# Altium schematic sheet Peripherals.SchDoc
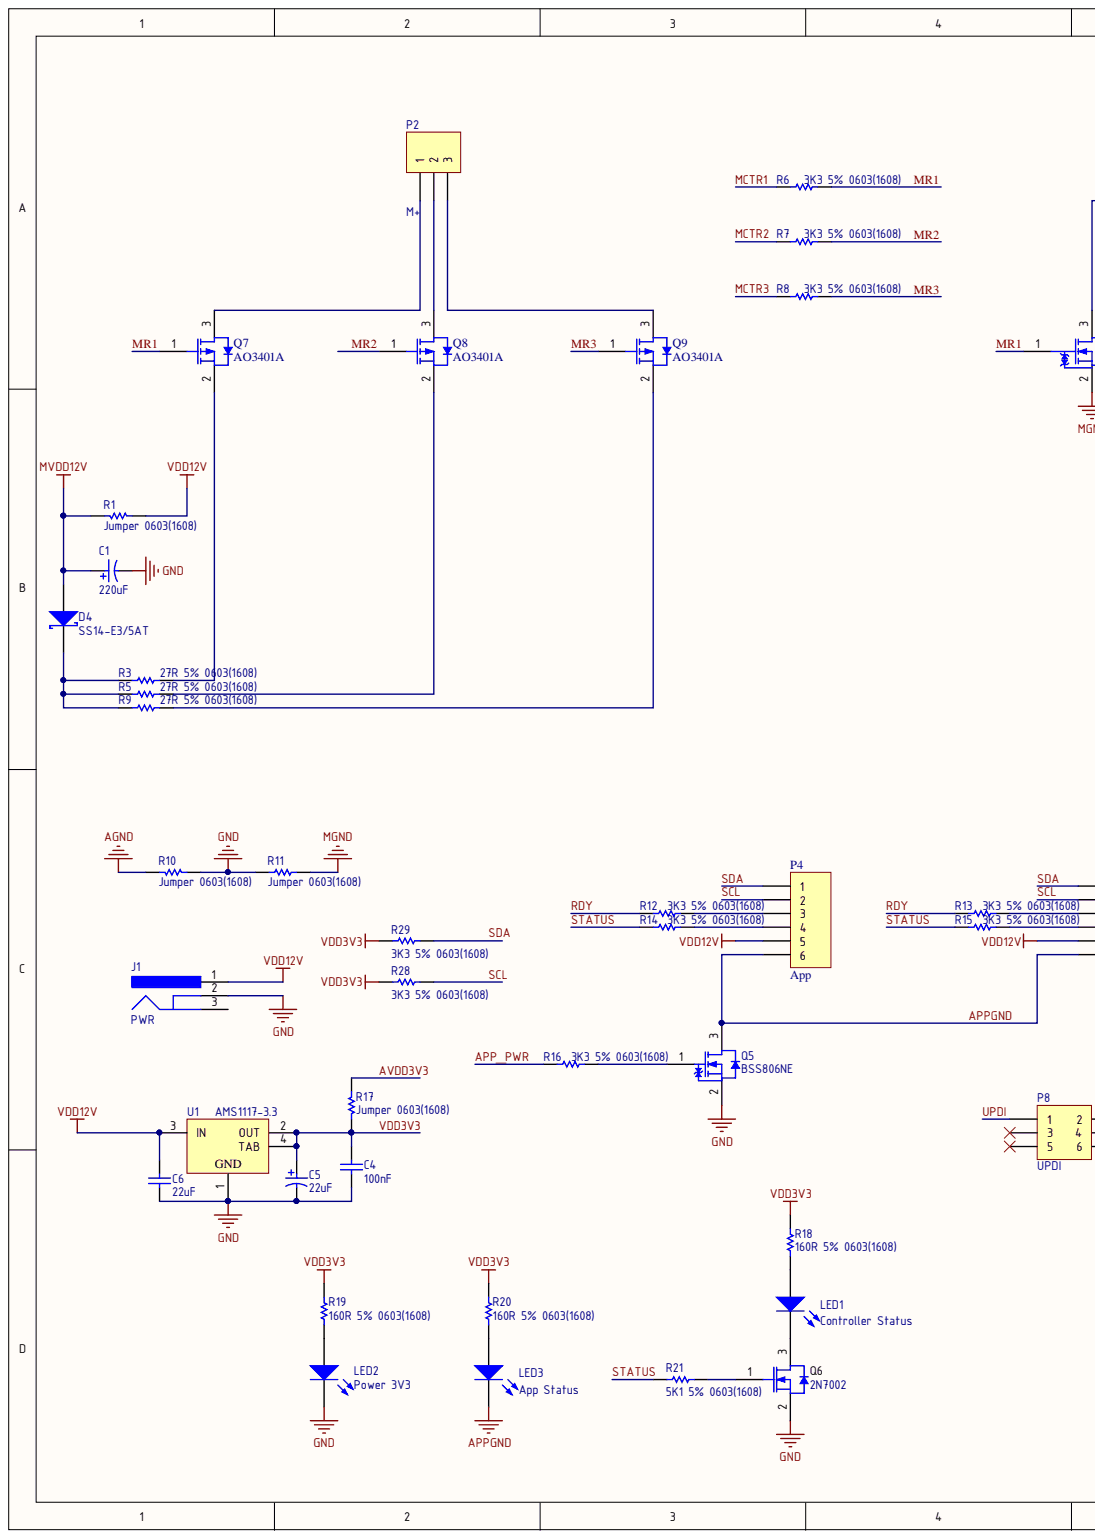
[diagram p0: Peripherals.SchDoc, left]
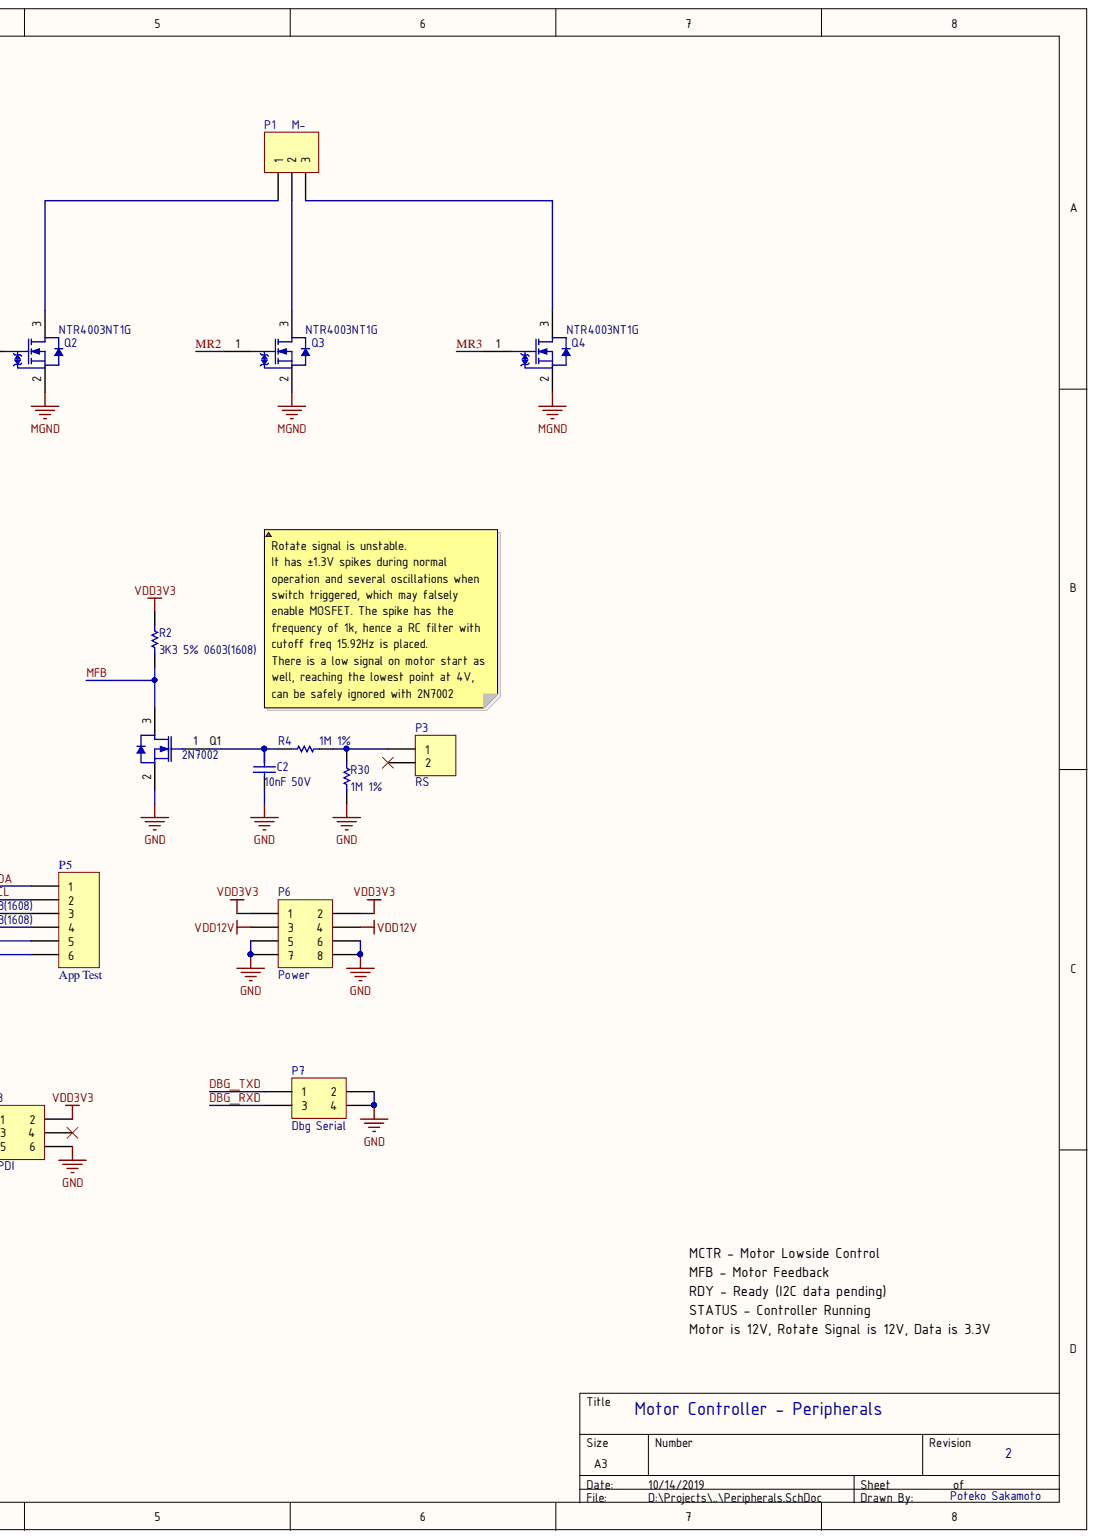
[diagram p1: Peripherals.SchDoc, right]

## Parts
C1  220uF  [PNSC-ZA-G_M]
C2  10nF 50V  value 10nF  [CAPC0603(1608)100_M]
C4  100nF  [CAPC0603(1608)100_M]
C5  22uF  [KEMT-A-3216-18-2_M]
C6  22uF  [CAPC0805(2012)145_M]
D4  SS14-E3/5AT  [VISH-DO-214AC-2_V]
J1  PWR  [KYCN-KLDX-0202-A_V]
LED1  Controller Status  [0805_A]
LED2  Power 3V3  [0805_A]
LED3  App Status  [0805_A]
P1  M-  [HDR1X3]
P2  M+  [HDR1X3]
P3  RS  [HDR1X2]
P4  App  [PH2.0-WS-6P]
P5  App Test  [HDR1X6]
P6  Power  [HDR2X4]
P7  Dbg Serial  [HDR2X2]
P8  UPDI  [HDR2X3]
Q1  2N7002  [INF-PG-SOT23_M]
Q2  NTR4003NT1G  [ONSC-SOT-23-3-318-08_V]
Q3  NTR4003NT1G  [ONSC-SOT-23-3-318-08_V]
Q4  NTR4003NT1G  [ONSC-SOT-23-3-318-08_V]
Q5  BSS806NE  [INF-PG-SOT23-3_N]
Q6  2N7002  [INF-PG-SOT23_M]
Q7  AO3401A  [AO-SOT-23-3L_V]
Q8  AO3401A  [AO-SOT-23-3L_V]
Q9  AO3401A  [AO-SOT-23-3L_V]
R1  Jumper 0603(1608)  [RESC0603(1608)_M]
R2  3K3 5% 0603(1608)  [RESC0603(1608)_M]
R3  27R 5% 0603(1608)  [RESC0603(1608)_M]
R4  1M 1%  [RESC0603(1608)_M]
R5  27R 5% 0603(1608)  [RESC0603(1608)_M]
R6  3K3 5% 0603(1608)  [RESC0603(1608)_M]
R7  3K3 5% 0603(1608)  [RESC0603(1608)_M]
R8  3K3 5% 0603(1608)  [RESC0603(1608)_M]
R9  27R 5% 0603(1608)  [RESC0603(1608)_M]
R10  Jumper 0603(1608)  [RESC0603(1608)_M]
R11  Jumper 0603(1608)  [RESC0603(1608)_M]
R12  3K3 5% 0603(1608)  [RESC0603(1608)_M]
R13  3K3 5% 0603(1608)  [RESC0603(1608)_M]
R14  3K3 5% 0603(1608)  [RESC0603(1608)_M]
R15  3K3 5% 0603(1608)  [RESC0603(1608)_M]
R16  3K3 5% 0603(1608)  [RESC0603(1608)_M]
R17  Jumper 0603(1608)  [RESC0603(1608)_M]
R18  160R 5% 0603(1608)  [RESC0603(1608)_M]
R19  160R 5% 0603(1608)  [RESC0603(1608)_M]
R20  160R 5% 0603(1608)  [RESC0603(1608)_M]
R21  5K1 5% 0603(1608)  [RESC0603(1608)_M]
R28  3K3 5% 0603(1608)  [RESC0603(1608)_M]
R29  3K3 5% 0603(1608)  [RESC0603(1608)_M]
R30  1M 1%  [RESC0603(1608)_M]
U1  AMS1117-3.3  [DCY0004A_M]

## Nets
AGND: R10.2
GND: C1.2 C2.1 C4.1 C5.2 C6.1 J1.2 LED2.1 P6.5 P6.6 P6.7 P6.8 P7.2 P7.4 P8.6 Q1.2 Q5.2 Q6.2 R10.1 R11.1 R30.1 U1.1
MGND: Q2.2 Q3.2 Q4.2 R11.2
MVDD12V: C1.1 D4.1 R1.2
VDD12V: C6.2 J1.1 P4.5 P5.5 P6.3 P6.4 R1.1 U1.3(IN)
APP_PWR: R16.2
APPGND: LED3.1 P4.6 P5.6 Q5.3
AVDD3V3: R17.1
DBG_RXD: P7.3
DBG_TXD: P7.1
MCTR1: R6.2
MCTR2: R7.2
MCTR3: R8.2
MFB: Q1.3 R2.2
MR1: Q2.1 Q7.1 R6.1
MR2: Q3.1 Q8.1 R7.1
MR3: Q4.1 Q9.1 R8.1
RDY: R12.2 R13.2
SCL: P4.2 P5.2 R28.2
SDA: P4.1 P5.1 R29.2
STATUS: R14.2 R15.2 R21.1
UPDI: P8.1
VDD3V3: C4.2 C5.1 P6.1 P6.2 P8.2 R2.1 R17.2 R18.2 R19.2 R20.2 R28.1 R29.1 U1.2(OUT) U1.4(TAB)
NetC2_2: C2.2 Q1.1 R4.1
NetD4_2: D4.2 R3.1 R5.1 R9.1
NetLED1_1: LED1.1 Q6.3
NetLED1_2: LED1.2 R18.1
NetLED2_2: LED2.2 R19.1
NetLED3_2: LED3.2 R20.1
NetP1_1: P1.1 Q2.3
NetP1_2: P1.2 Q3.3
NetP1_3: P1.3 Q4.3
NetP2_1: P2.1 Q7.3
NetP2_2: P2.2 Q8.3
NetP2_3: P2.3 Q9.3
NetP3_1: P3.1 R4.2 R30.2
NetP4_3: P4.3 R12.1
NetP4_4: P4.4 R14.1
NetP5_3: P5.3 R13.1
NetP5_4: P5.4 R15.1
NetQ5_1: Q5.1 R16.1
NetQ6_1: Q6.1 R21.2
NetQ7_2: Q7.2 R3.2
NetQ8_2: Q8.2 R5.2
NetQ9_2: Q9.2 R9.2

Not connected: J1 3; P3 2; P8 3 4 5

## Library data of the parts
C1 = CMP-2000-06221-1: Conductive Polymer Hybrid Aluminum Electrolytic Capacitor, 220 uF, +/- 20%, 50 V, -55 to 105 degC, 2-Pin SMD (G), RoHS, Tape and Reel; Brand: Panasonic; Capacitance: 100uF; Case Diameter: 10mm; Case Height: 10.5mm; Case Length: 10.2mm; ESR: 28mOhms; Load Life: 10000Hour; Ripple Current: 2A; Voltage Rating DC: 50V; Component Kind: Standard; Datasheet URL: https://industrial.panasonic.com/cdbs/www-data/pdf/RDD0000/DMD0000COL56.pdf; Datasheet Version: 06/2016; Factory Pack Quantity: 500; Height: 10.5mm; Library Name: Altium Content Vault; Manufacturer: Panasonic; Manufacturer URL: http://www.panasonic.com/; Maximum Operating Temperature: +105C; Minimum Operating Temperature: -55C; Mounting Technology: SurfaceMount; Package Description: 2-Pin Surface Mount Device, Body 10.3 x 10.3 mm; Package Reference: ZA-G; Packaging: Reel; Product: AluminumElectrolyticCapacitors; Product Category: AluminumOrganicPolymerCapacitors; RoHS: Details; Series: ZA; Termination Style: SMD/SMT; Tolerance: 20%
C2 = CMP-1035-02320-1: CAP 10nF 50V ±5% 0603 (1608 Metric) Thickness 1mm SMD; Case-EIA: 0603; Case-Metric: 1608; Tolerance: Â±5%; Component Kind: Standard; Library Name: Altium Content Vault; Max Thickness: 1 mm; Rated Voltage: 50 V; Technology: SMT
C4 = CMP-1035-02592-1: CAP 100nF 50V ±10% 0603 (1608 Metric) Thickness 1mm SMD; Case-EIA: 0603; Case-Metric: 1608; Tolerance: Â±10%; Component Kind: Standard; Library Name: Altium Content Vault; Max Thickness: 1 mm; Rated Voltage: 50 V; Technology: SMT; Value: 100nF
C5 = CMP-2000-05173-1: Capacitor, Tantalum, 22 uF, +/- 10%, 16 V, -55 to 125 degC, 2-Pin SMD (1206), RoHS, Tape and Reel; Manufacturer: Kemet; Capacitance: 10uF; Case Style: Molded; DCL: 1.6uA; DF: 8%; ESR: 7ohm; Insulation: NotRequired; Lead Spacing (nom): NotRequiredmm; Polarity: Polar; Product Depth (mm): 1.6mm; Product Length (mm): 3.2mm; Voltage: 16VDC; Case Code: A; Component Kind: Standard; Construction: SMTChip; Datasheet URL: http://www.farnell.com/datasheets/1824869.pdf; Datasheet Version: Apr-14; Failure Rate: NotRequired; Length W/Weld: NotRequiredmm; Library Name: Altium Content Vault; Manufacturer URL: http://www.kemet.com/; Mounting Style: SurfaceMount; Operating Temp Range: -55Cto125C; Package / Case: 3216-18; Package Description: 2-Pin Surface Mount Device, Body 3.2 x 1.6 mm; Package Reference: 1206; Packaging: TapeandReel; Product Diameter (mm): NotRequiredmm; Product Height (mm): 1.6mm; Seal: NotRequired; Seated Plane Height: NotRequiredmm; Tolerance (+ or -): 10%; Wire Form: NotRequired
C6 = CMP-1036-04942-1: CAP 22uF 10V ±10% 0805 (2012 Metric) Thickness 1.45mm SMD; Case-EIA: 0805; Case-Metric: 2012; Tolerance: Â±10%; Component Kind: Standard; Library Name: Altium Content Vault; Max Thickness: 1.45 mm; Rated Voltage: 10 V; Technology: SMT; Value: 22uF
D4 = CMP-0549-00004-1: Schottky Barrier Rectifier, 40 V Maximum DC Blocking Voltage, -65 to 125 degC, 2-Pin SMA Case, RoHS; Manufacturer: Vishay Semiconductor; IF(AV): 1 A Max.; IFSM: 40 A; VDC: 40 V Max.; VRMS: 28 V Max.; Component Kind: Standard; ComponentLink1Description: Manufacturer URL; ComponentLink1URL: http://www.vishay.com/; ComponentLink2Description: Datasheet; ComponentLink2URL: http://www.vishay.com/docs/88746/ss12.pdf; ComponentLink3Description: Package Specification; ComponentLink3URL: http://www.vishay.com/docs/88746/ss12.pdf; DatasheetVersion: 12/17/2012; Library Name: Altium Content Vault; Mounting Technology: Surface Mount; Operating Temperature: -65 to 125 degC; PackageDescription: 2-Pin SMD, Body 4.245 x 2.665 mm, Height 2.29 mm; PackageReference: DO-214AC-2; PackageVersion: Dec-12; PartNumber: SS14-E3/5AT; RoHS: TRUE; VRRM: 40 V Max.
J1 = CMP-1673-00001-5: DC Power Jack, 24 V, 3.5 A, -25 to 85 degC, 3-Pin THD, RoHS; Manufacturer: Kycon; Current Rating: 3.5 A; Voltage Rating: 24 V; Colour: Black; Component Kind: Standard; ComponentLink1Description: Manufacturer URL; ComponentLink1URL: http://www.kycon.com/; ComponentLink2Description: Datasheet; ComponentLink2URL: http://www.kycon.com/Pub_Eng_Draw/KLDX-0202-X.PDF; Connector Type: Power; Contact Gender: Female; Contact Material: Brass; Contact Plating: Tin; DatasheetVersion: Rev. D3, 06/2013; Height: 10.8 mm; Housing Material: PBT; Length: 9.2 mm; Library Name: Altium Content Vault; Max Operating Temperature: 85 degC; Min Operating Temperature: -25 degC; Mount: Through Hole; Mounting Technology: Through Hole; PackageDescription: 3-Pin Through Hole Device, Body 14.5 x 9 mm; Package Quantity: 500; PackageReference: KLDX-0202-A; Pins: 2; RoHSCompliant: true
LED1 LED3 = CMP-1426-00008-1: SMD mono-color Chip LED, WL-SMCW, Blue; Manufacturer: Wuerth Elektronik; PartNumber: 150080BS75000; Color: Blue; Component Kind: Standard; ComponentLink1Description: Manufacturer URL; ComponentLink1URL: http://www.we-online.com; ComponentLink2Description: Datasheet; ComponentLink2URL: http://katalog.we-online.com/led/datasheet/150080BS75000.pdf; Library Name: Altium Content Vault; PackageReference: 0805_A
LED2 = CMP-1426-00007-1: SMD mono-color Chip LED, WL-SMCW, Green; Manufacturer: Wuerth Elektronik; PartNumber: 150080GS75000; Color: Green; Component Kind: Standard; ComponentLink1Description: Manufacturer URL; ComponentLink1URL: http://www.we-online.com; ComponentLink2Description: Datasheet; ComponentLink2URL: http://katalog.we-online.com/led/datasheet/150080GS75000.pdf; Library Name: Altium Content Vault; PackageReference: 0805_A
P1 P2 = Header 3: Header, 3-Pin; Component Kind: Standard; LatestRevisionDate: 17-Jul-2002; LatestRevisionNote: Re-released for DXP Platform.; Library Name: Miscellaneous Connectors.IntLib; Signal Integrity: Connector; Publisher: Altium Limited
P3 = Header 2: Header, 2-Pin; Component Kind: Standard; LatestRevisionDate: 17-Jul-2002; LatestRevisionNote: Re-released for DXP Platform.; Library Name: Miscellaneous Connectors.IntLib; Signal Integrity: Connector; Publisher: Altium Limited
P4 P5 = Header 6: Header, 6-Pin; Component Kind: Standard; LatestRevisionDate: 17-Jul-2002; LatestRevisionNote: Re-released for DXP Platform.; Library Name: Miscellaneous Connectors.IntLib; Signal Integrity: Connector; Publisher: Altium Limited
P6 = Header 4X2: Header, 4-Pin, Dual row; Component Kind: Standard; LatestRevisionDate: 17-Jul-2002; LatestRevisionNote: Re-released for DXP Platform.; Library Name: Miscellaneous Connectors.IntLib; Signal Integrity: Connector; Publisher: Altium Limited
P7 = Header 2X2: Header, 2-Pin, Dual row; Component Kind: Standard; LatestRevisionDate: 17-Jul-2002; LatestRevisionNote: Re-released for DXP Platform.; Library Name: Miscellaneous Connectors.IntLib; Signal Integrity: Connector; Publisher: Altium Limited
P8 = Header 3X2: Header, 3-Pin, Dual row; Component Kind: Standard; LatestRevisionDate: 17-Jul-2002; LatestRevisionNote: Re-released for DXP Platform.; Library Name: Miscellaneous Connectors.IntLib; Signal Integrity: Connector; Publisher: Altium Limited
Q1 Q6 = CMP-1149-00026-1: N-Channel OptiMOS Small-Signal-Transistor, 60 V VDS, 0.3 A ID, -55 to 150 degC, PG-SOT23, Reel, Green; Manufacturer: Infineon; Green: TRUE; ID (max): 0.3Â A; IDpuls (max): 1.2Â A; Ptot (max): 0.5Â W; QG: 0.4Â nC; RDS (on) (max): 3,000 mOhm; RDS (on) (max) (@4.5V): 4,000 mOhm; RthJA (max): 250 K/W; VDS (max): 60 V; VGS(th) (max): 2.5Â V; VGS(th) (min): 1.5Â V; Component Kind: Standard; ComponentLink1Description: Manufacturer URL; ComponentLink1URL: http://www.infineon.com/; ComponentLink2Description: Datasheet; ComponentLink2URL: http://www.infineon.com/dgdl/2N7002_Rev2.4.pdf?folderId=db3a3043156fd573011622e10b5c1f67&fileId=db3a304319bc939a0119c2a9461e79e6; ComponentLink3Description: Package Specification; ComponentLink3URL: http://www.infineon.com/dgdl/SOT23-Package_Overview.pdf?folderId=db3a30431936bc4b0119532290053c66&fileId=db3a30431936bc4b0119533468123c85; DatasheetVersion: Rev. 2.4; Halogen-free: TRUE; Library Name: Altium Content Vault; Mounting Technology: Surface Mount; PackageDescription: 3-Pin Small Outline Transistor Package, 2.9 x 1.3 mm Body, 0.95 mm Pitch; PackageReference: PG-SOT23; PackageVersion: Apr-08; Packing: Reel; PartNumber: 2N7002
Q2-Q4 = CMP-1058-00759-1: Small Signal MOSFET, 30 V, 0.56 A, Single N-Channe, 3-Pin SOT-23, Pb-Free, Tape and Reel; Manufacturer: On Semiconductor; Channel Polarity: N Channel; Ciss Typ (pF): 21; Coss Typ (pF): 19.7; Crss Typ (pF): 8.1; ID Max (A): 0.56; V(BR)DSS Min (V): 30; VGS(th) Max (V): 1.4; VGS Max (V): 20; Component Kind: Standard; ComponentLink1Description: Manufacturer URL; ComponentLink1URL: http://www.onsemi.com/; ComponentLink2Description: Datasheet; ComponentLink2URL: http://www.onsemi.cn/pub_link/Collateral/NTR4003N-D.PDF; ComponentLink3Description: Package Specification; ComponentLink3URL: http://www.onsemi.cn/pub_link/Collateral/318-08.PDF; Configuration: Single; DatasheetVersion: Rev. 3; Library Name: Altium Content Vault; Mounting Technology: Surface Mount; PackageDescription: 3-Pin Small Outline Transistor, Body 2.92 x 1.3 mm, Pitch 0.95 mm; PackageReference: SOT-23-3-318-08; Package Type: SOT-23-3; PackageVersion: Rev. AP, 11/2009; Packing: Tape and Reel; PartNumber: NTR4003NT1G; RoHS: Pb-Free
Q5 = CMP-1149-00037-1: N-Channel OptiMOS(TM)2 Small-Signal-Transistor, 20 V VDS, 2.3 A ID, -55 to 150 degC, PG-SOT23-3, Reel, Green; Manufacturer: Infineon; Green: TRUE; ID (max): 2.3Â A; IDpuls (max): 9.3Â A; Mode: Enhancement; Polarity: FALSE; Ptot (max): 0.5Â W; QG: 1.7Â nC; RthJA (max): 250Â K/W; VDS (max): 20Â V; VGS(th) (max): 0.75Â V; VGS(th) (min): 0.3Â V; Component Kind: Standard; ComponentLink1Description: Manufacturer URL; ComponentLink1URL: http://www.infineon.com/; ComponentLink2Description: Datasheet; ComponentLink2URL: http://www.infineon.com/dgdl/BSS806NE_Rev2+01.pdf?folderId=db3a3043156fd573011622e10b5c1f67&fileId=db3a304340f610c201410d1548de3366; ComponentLink3Description: Package Specification; ComponentLink3URL: http://www.infineon.com/dgdl/SOT23-Package_Overview.pdf?folderId=db3a30431936bc4b0119532290053c66&fileId=db3a30431936bc4b0119533468123c85; DatasheetVersion: Rev. 2.01; Halogen-free: TRUE; Library Name: Altium Content Vault; Mounting: SMD; Mounting Technology: Surface Mount; PackageDescription: 3-Pin Small Outline Transistor Package, 1.25 x 2 mm Body, 0.65 mm Pitch; PackageReference: PG-SOT23-3; PackageVersion: Apr-08; Packing: Reel; PartNumber: BSS806NE
Q7-Q9 = CMP-2000-05778-1: P-Channel MOSFET, 30 V, 4 A, -55 to 150 degC, 3-Pin SOT-23-3L, RoHS, Tape and Reel; Manufacturer: Alpha and Omega; Channel Mode: Enhancement; Continuous Drain Current: 4A; Drain-Source On-Res: 0.044ohm; Drain-Source On-Volt: 30V; Drain Efficiency: NotRequired%; Frequency (Max): NotRequiredMHz; Gate-Source Voltage (Max): ?12V; Noise Figure: NotRequireddB; Number of  Elements: 1; Output Power (Max): NotRequiredW; Polarity: P; Power Dissipation: 1.4W; Rad Hardened: No; Type: PowerMOSFET; Component Kind: Standard; Datasheet URL: http://www.aosmd.com/res/data_sheets/AO3401A.pdf; Datasheet Version: Rev. 3, 03/2011; Drain Current (Max): 4A; Library Name: Altium Content Vault; Manufacturer URL: http://www.aosmd.com/; Mounting: SurfaceMount; Mounting Technology: SurfaceMount; Operating Temperature Classification: Military; Operating Temp Range: -55Cto150C; Package Description: 3-Pin Small Outline Transistor, Body 2.95 x 1.6 mm, Pitch 0.95 mm; Package Reference: SOT-23-3L; Package Type: SOT-23; Package URL: http://www.aosmd.com/res/packaging_information/SOT-23-3L.pdf; Pin Count: 3; Power Gain: NotRequireddB
R1 R10 R11 R17 = CMP-1012-00001-1: Jumper 0603 (1608 Metric); Case-EIA: 0603; Case-Metric: 1608; Power: None; Value: 0.00 Ohm; Component Kind: Standard; Library Name: Altium Content Vault; Technology: SMT; Tolerance: None
R2 R6-R8 R12-R16 R28 R29 = CMP-1012-00086-1: 3K3 0.1W 5% 0603 (1608 Metric)  SMD; Case-EIA: 0603; Case-Metric: 1608; Value: 3300.00 Ohm; Component Kind: Standard; Library Name: Altium Content Vault; Power: 0.1 W; Technology: SMT; Tolerance: 5 %
R3 R5 R9 = CMP-1012-00036-1: 27R 0.1W 5% 0603 (1608 Metric)  SMD; Case-EIA: 0603; Case-Metric: 1608; Value: 27.00 Ohm; Component Kind: Standard; Library Name: Altium Content Vault; Power: 0.1 W; Technology: SMT; Tolerance: 5 %
R4 R30 = CMP-1012-00849-1: 1M 0.1W 1% 0603 (1608 Metric)  SMD; Case-EIA: 0603; Case-Metric: 1608; Value: 1000000.00 Ohm; Component Kind: Standard; Library Name: Altium Content Vault; Power: 0.1 W; Technology: SMT; Tolerance: 1 %
R18-R20 = CMP-1012-00055-1: 160R 0.1W 5% 0603 (1608 Metric)  SMD; Case-EIA: 0603; Case-Metric: 1608; Value: 160.00 Ohm; Component Kind: Standard; Library Name: Altium Content Vault; Power: 0.1 W; Technology: SMT; Tolerance: 5 %
R21 = CMP-1012-00091-1: 5K1 0.1W 5% 0603 (1608 Metric)  SMD; Case-EIA: 0603; Case-Metric: 1608; Value: 5100.00 Ohm; Component Kind: Standard; Library Name: Altium Content Vault; Power: 0.1 W; Technology: SMT; Tolerance: 5 %
U1 = CMP-0062-01659-4: 800mA Low-Dropout Linear Regulator, 4-pin SOT-223; Manufacturer: Texas Instruments; PartNumber: LM1117MPX-3.3; CompType: LDO IC; High-Reliability: Y; PackageTopMarking: XYTT*N05A; PowerWise: N; Code_IPC: SOT223-4; Code_JEDEC: TO-261-AA; Component Kind: Standard; ComponentLink1Description: Datasheet URL; ComponentLink1URL: http://www.ti.com/general/docs/lit/getliterature.tsp?genericPartNumber=LM1117-N&fileType=pdf; Library Name: Altium Content Vault; PackageDescription: Molded SOT-223, 6.50 x 3.56 x 1.6mm Body, 4 Lead, 2.3mm Pitch; PackageReference: DCY0004A; PinCount: 4; RoHS: N
